# Altium schematic sheet sem02_8600sd_ind.SchDoc
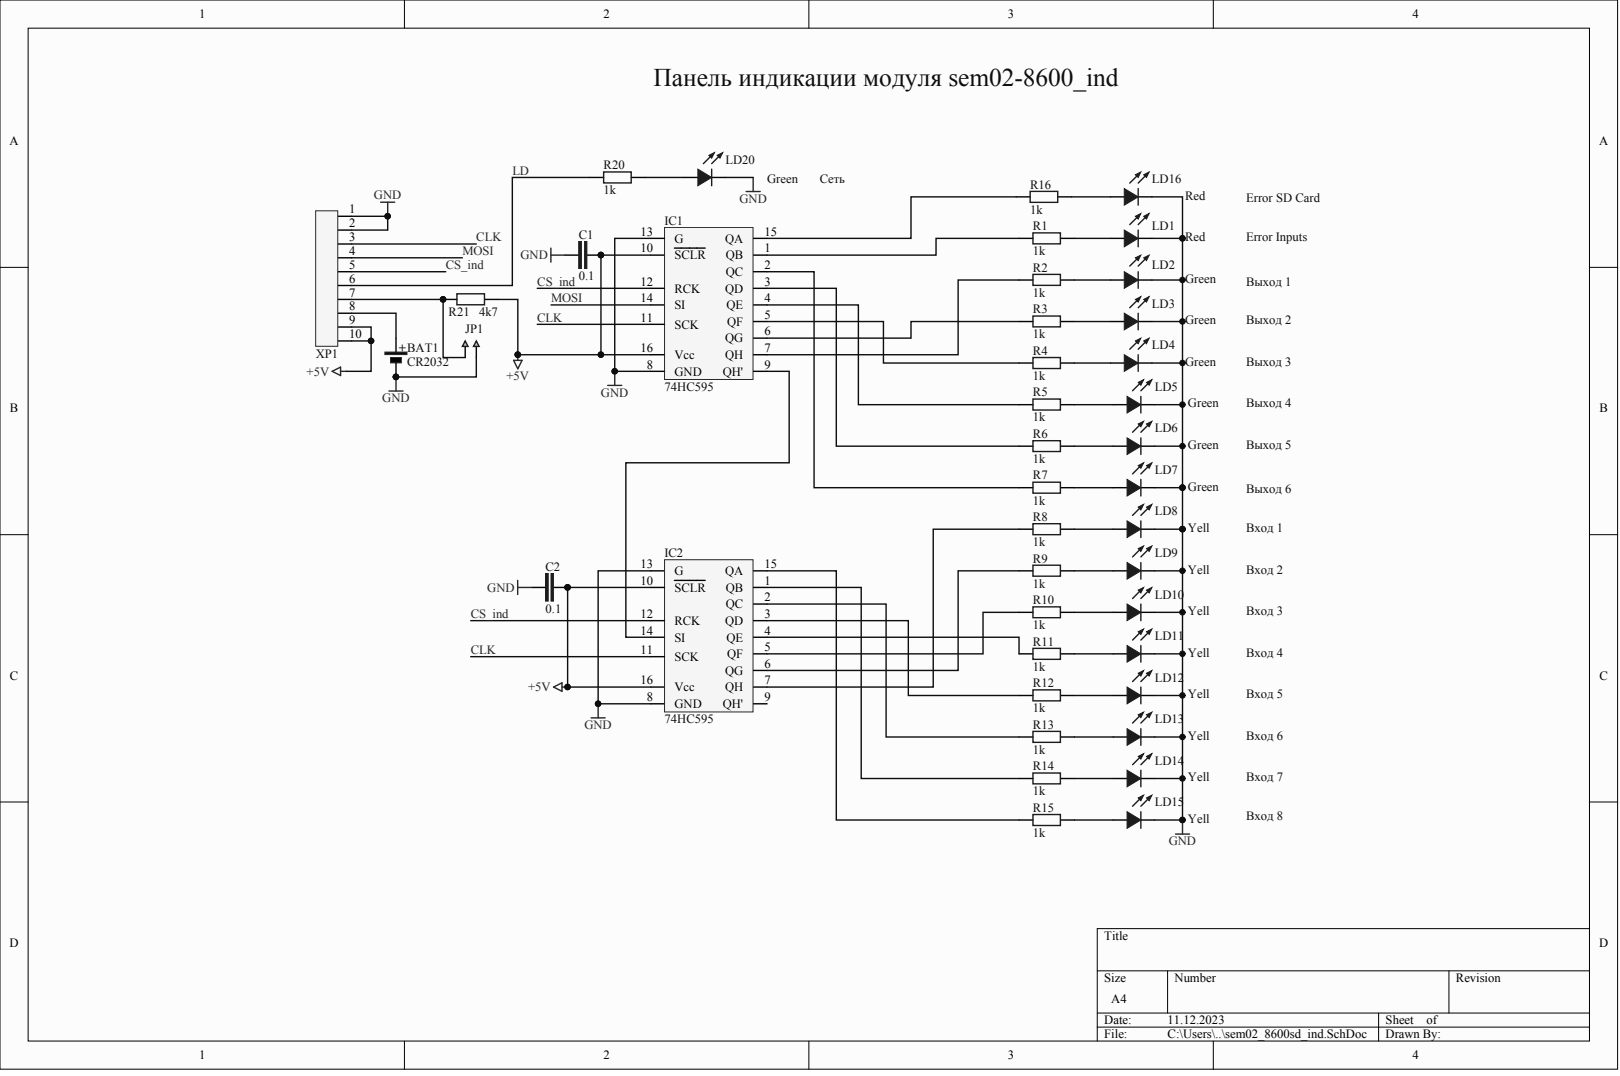
[diagram p0: sem02_8600sd_ind.SchDoc]

## Parts
BAT1  CR2032
C1  0.1
C2  0.1
IC1  74HC595
IC2  74HC595
JP1  jamper  (value not drawn)
LD1  Red
LD2  Green
LD3  Green
LD4  Green
LD5  Green
LD6  Green
LD7  Green
LD8  Yell
LD9  Yell
LD10  Yell
LD11  Yell
LD12  Yell
LD13  Yell
LD14  Yell
LD15  Yell
LD16  Red
LD20  Green
R1  1k
R2  1k
R3  1k
R4  1k
R5  1k
R6  1k
R7  1k
R8  1k
R9  1k
R10  1k
R11  1k
R12  1k
R13  1k
R14  1k
R15  1k
R16  1k
R20  1k
R21  4k7
XP1  Conn10x1  (value not drawn)

## Nets
+5V: C1.2 C2.2 IC1.10(SCLR) IC1.16(Vcc) IC2.10(SCLR) IC2.16(Vcc) R21.1 XP1.9 XP1.10
GND: BAT1.2 C1.1 C2.1 IC1.8(GND) IC1.13(G) IC2.8(GND) IC2.13(G) JP1.2 LD1.2 LD2.2 LD3.2 LD4.2 LD5.2 LD6.2 LD7.2 LD8.2 LD9.2 LD10.2 LD11.2 LD12.2 LD13.2 LD14.2 LD15.2 LD16.2 LD20.2 XP1.1 XP1.2
CLK: IC1.11(SCK) IC2.11(SCK) XP1.3
CS_IND: IC1.12(RCK) IC2.12(RCK) XP1.5
LD: R20.2 XP1.6
MOSI: IC1.14(SI) XP1.4
NetBAT1_1: BAT1.1 XP1.8
NetIC1_1: IC1.1(QB) R1.2
NetIC1_2: IC1.2(QC) R7.2
NetIC1_3: IC1.3(QD) R6.2
NetIC1_4: IC1.4(QE) R5.2
NetIC1_5: IC1.5(QF) R4.2
NetIC1_6: IC1.6(QG) R3.2
NetIC1_7: IC1.7(QH) R2.2
NetIC1_9: IC1.9(QH') IC2.14(SI)
NetIC1_15: IC1.15(QA) R16.2
NetIC2_1: IC2.1(QB) R14.2
NetIC2_2: IC2.2(QC) R13.2
NetIC2_3: IC2.3(QD) R12.2
NetIC2_4: IC2.4(QE) R11.2
NetIC2_5: IC2.5(QF) R10.2
NetIC2_6: IC2.6(QG) R9.2
NetIC2_7: IC2.7(QH) R8.2
NetIC2_15: IC2.15(QA) R15.2
NetJP1_1: JP1.1 R21.2 XP1.7
NetLD1_1: LD1.1 R1.1
NetLD2_1: LD2.1 R2.1
NetLD3_1: LD3.1 R3.1
NetLD4_1: LD4.1 R4.1
NetLD5_1: LD5.1 R5.1
NetLD6_1: LD6.1 R6.1
NetLD7_1: LD7.1 R7.1
NetLD8_1: LD8.1 R8.1
NetLD9_1: LD9.1 R9.1
NetLD10_1: LD10.1 R10.1
NetLD11_1: LD11.1 R11.1
NetLD12_1: LD12.1 R12.1
NetLD13_1: LD13.1 R13.1
NetLD14_1: LD14.1 R14.1
NetLD15_1: LD15.1 R15.1
NetLD16_1: LD16.1 R16.1
NetLD20_1: LD20.1 R20.1

Not connected: IC2 9(QH')
